# Altium schematic sheet CPU.SchDoc(CPU)
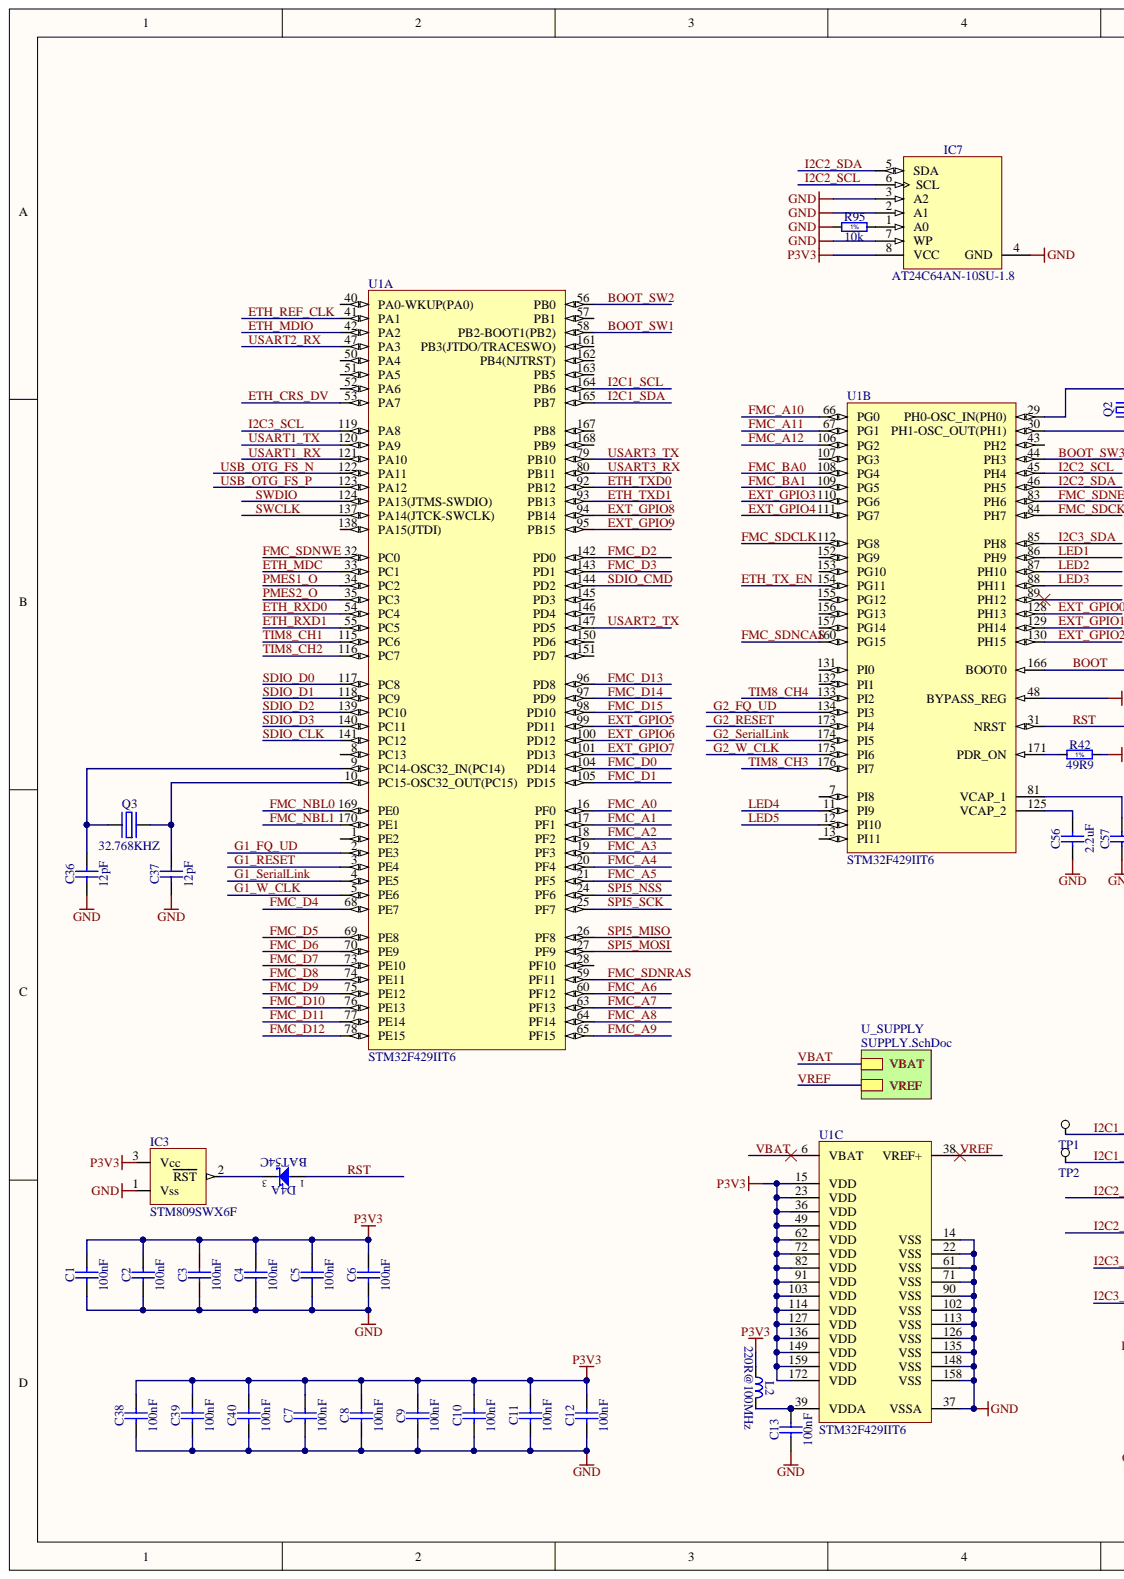
[diagram p0: CPU.SchDoc, left]
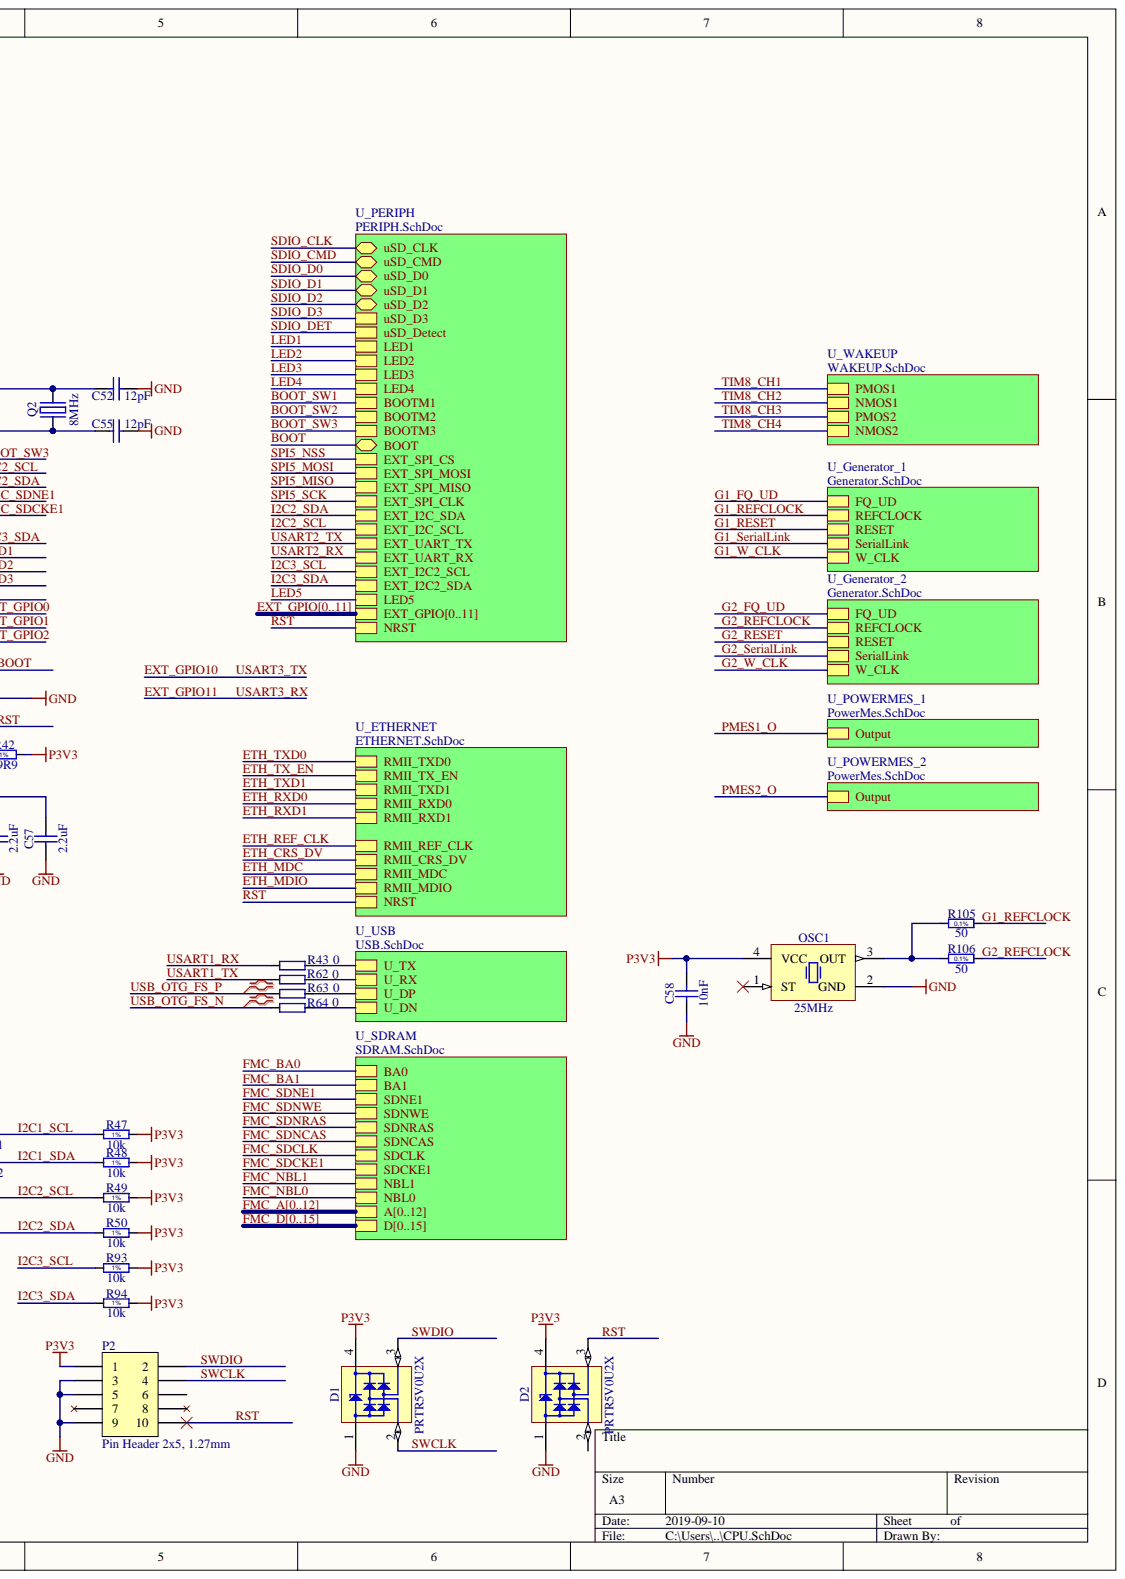
[diagram p1: CPU.SchDoc, right]

## Parts
C1  100nF  [CAPC1005X55N]
C2  100nF  [CAPC1005X55N]
C3  100nF  [CAPC1005X55N]
C4  100nF  [CAPC1005X55N]
C5  100nF  [CAPC1005X55N]
C6  100nF  [CAPC1005X55N]
C7  100nF  [CAPC1005X55N]
C8  100nF  [CAPC1005X55N]
C9  100nF  [CAPC1005X55N]
C10  100nF  [CAPC1005X55N]
C11  100nF  [CAPC1005X55N]
C12  100nF  [CAPC1005X55N]
C13  100nF  [CAPC1005X55N]
C36  12pF  [CAPC1005X55N]
C37  12pF  [CAPC1005X55N]
C38  100nF  [CAPC1005X55N]
C39  100nF  [CAPC1005X55N]
C40  100nF  [CAPC1005X55N]
C52  12pF  [CAPC1005X55N]
C55  12pF  [CAPC1005X55N]
C56  2.2uF  [CAPC1608X87N]
C57  2.2uF  [CAPC1608X87N]
C58  10nF  [CAPC1005X55N]
D1  PRTR5V0U2X  [SOT190P230X110-4N]
D2  PRTR5V0U2X  [SOT190P230X110-4N]
D4  BAT54C  [SOT95P240X130-3N]  (part A)
IC3  STM809SWX6F  [SOT95P229X119-3N]
IC7  AT24C64AN-10SU-1.8  [SOIC127P600X175-8N]
L2  220R@100MHz  [INDC2012X105N]
OSC1  25MHz  [OSC_MICREL_2520]
P2  Pin Header 2x5, 1.27mm  [HDR_2x5-SMD-1.27mm-K]
Q2  8MHz  [XTAL_TXC_7A]
Q3  32.768KHZ  [XTAL_ABRACON_ABS09]
R42  49R9  [RESC1005X40N]
R43  0  [RESC1005X40N]
R47  10k  [RESC1005X40N]
R48  10k  [RESC1005X40N]
R49  10k  [RESC1005X40N]
R50  10k  [RESC1005X40N]
R62  0  [RESC1005X40N]
R63  0  [RESC1005X40N]
R64  0  [RESC1005X40N]
R93  10k  [RESC1005X40N]
R94  10k  [RESC1005X40N]
R95  10k  [RESC1005X40N]
R105  50  [RESC1005X35N]
R106  50  [RESC1005X35N]
TP1  TP  [TP]  (no BOM)
TP2  TP  [TP]  (no BOM)
U1  STM32F429IIT6  [STM-LQFP176_N]  (parts A,B,C)

## Nets
GND: C1.1 C2.1 C3.1 C4.1 C5.1 C6.1 C7.1 C8.1 C9.1 C10.1 C11.1 C12.1 C13.1 C36.1 C37.1 C38.1 C39.1 C40.1 C52.1 C55.1 C56.1 C57.1 C58.1 D1.1 D2.1 IC3.1 IC7.2(A1) IC7.3(A2) IC7.4(GND) IC7.7(WP) OSC1.2(GND) P2.3 P2.5 P2.9 R95.1 U1.14(VSS) U1.22(VSS) U1.37(VSSA) U1.48(BYPASS_REG) U1.61(VSS) U1.71(VSS) U1.90(VSS) U1.102(VSS) U1.113(VSS) U1.126(VSS) U1.135(VSS) U1.148(VSS) U1.158(VSS)
P3V3: C1.2 C2.2 C3.2 C4.2 C5.2 C6.2 C7.2 C8.2 C9.2 C10.2 C11.2 C12.2 C38.2 C39.2 C40.2 C58.2 D1.4 D2.4 IC3.3 IC7.8(VCC) L2.1 OSC1.4(VCC) P2.1 R42.2 R47.2 R48.2 R49.2 R50.2 R93.2 R94.2 U1.15(VDD) U1.23(VDD) U1.36(VDD) U1.49(VDD) U1.62(VDD) U1.72(VDD) U1.82(VDD) U1.91(VDD) U1.103(VDD) U1.114(VDD) U1.127(VDD) U1.136(VDD) U1.149(VDD) U1.159(VDD) U1.172(VDD)
BOOT: U1.166(BOOT0)
BOOT_SW1: U1.58(PB2-BOOT1(PB2))
BOOT_SW2: U1.56(PB0)
BOOT_SW3: U1.44(PH3)
ETH_CRS_DV: U1.53(PA7)
ETH_MDC: U1.33(PC1)
ETH_MDIO: U1.42(PA2)
ETH_REF_CLK: U1.41(PA1)
ETH_RXD0: U1.54(PC4)
ETH_RXD1: U1.55(PC5)
ETH_TX_EN: U1.154(PG11)
ETH_TXD0: U1.92(PB12)
ETH_TXD1: U1.93(PB13)
EXT_GPIO0: U1.128(PH13)  [also labelled EXT_GPIO]
EXT_GPIO1: U1.129(PH14)  [also labelled EXT_GPIO]
EXT_GPIO2: U1.130(PH15)  [also labelled EXT_GPIO]
EXT_GPIO3: U1.110(PG6)  [also labelled EXT_GPIO]
EXT_GPIO4: U1.111(PG7)  [also labelled EXT_GPIO]
EXT_GPIO5: U1.99(PD11)  [also labelled EXT_GPIO]
EXT_GPIO6: U1.100(PD12)  [also labelled EXT_GPIO]
EXT_GPIO7: U1.101(PD13)  [also labelled EXT_GPIO]
EXT_GPIO8: U1.94(PB14)  [also labelled EXT_GPIO]
EXT_GPIO9: U1.95(PB15)  [also labelled EXT_GPIO]
EXT_GPIO10: U1.79(PB10)  [also labelled EXT_GPIO]
EXT_GPIO11: U1.80(PB11)  [also labelled EXT_GPIO]
FMC_A0: U1.16(PF0)  [also labelled FMC_A]
FMC_A1: U1.17(PF1)  [also labelled FMC_A]
FMC_A2: U1.18(PF2)  [also labelled FMC_A]
FMC_A3: U1.19(PF3)  [also labelled FMC_A]
FMC_A4: U1.20(PF4)  [also labelled FMC_A]
FMC_A5: U1.21(PF5)  [also labelled FMC_A]
FMC_A6: U1.60(PF12)  [also labelled FMC_A]
FMC_A7: U1.63(PF13)  [also labelled FMC_A]
FMC_A8: U1.64(PF14)  [also labelled FMC_A]
FMC_A9: U1.65(PF15)  [also labelled FMC_A]
FMC_A10: U1.66(PG0)  [also labelled FMC_A]
FMC_A11: U1.67(PG1)  [also labelled FMC_A]
FMC_A12: U1.106(PG2)  [also labelled FMC_A]
FMC_BA0: U1.108(PG4)
FMC_BA1: U1.109(PG5)
FMC_D0: U1.104(PD14)  [also labelled FMC_D]
FMC_D1: U1.105(PD15)  [also labelled FMC_D]
FMC_D2: U1.142(PD0)  [also labelled FMC_D]
FMC_D3: U1.143(PD1)  [also labelled FMC_D]
FMC_D4: U1.68(PE7)  [also labelled FMC_D]
FMC_D5: U1.69(PE8)  [also labelled FMC_D]
FMC_D6: U1.70(PE9)  [also labelled FMC_D]
FMC_D7: U1.73(PE10)  [also labelled FMC_D]
FMC_D8: U1.74(PE11)  [also labelled FMC_D]
FMC_D9: U1.75(PE12)  [also labelled FMC_D]
FMC_D10: U1.76(PE13)  [also labelled FMC_D]
FMC_D11: U1.77(PE14)  [also labelled FMC_D]
FMC_D12: U1.78(PE15)  [also labelled FMC_D]
FMC_D13: U1.96(PD8)  [also labelled FMC_D]
FMC_D14: U1.97(PD9)  [also labelled FMC_D]
FMC_D15: U1.98(PD10)  [also labelled FMC_D]
FMC_NBL0: U1.169(PE0)
FMC_NBL1: U1.170(PE1)
FMC_SDCKE1: U1.84(PH7)
FMC_SDCLK: U1.112(PG8)
FMC_SDNCAS: U1.160(PG15)
FMC_SDNE1: U1.83(PH6)
FMC_SDNRAS: U1.59(PF11)
FMC_SDNWE: U1.32(PC0)
G1_FQ_UD: U1.2(PE3)
G1_REFCLOCK: R105.2
G1_RESET: U1.3(PE4)
G1_SerialLink: U1.4(PE5)
G1_W_CLK: U1.5(PE6)
G2_FQ_UD: U1.134(PI3)
G2_REFCLOCK: R106.2
G2_RESET: U1.173(PI4)
G2_SerialLink: U1.174(PI5)
G2_W_CLK: U1.175(PI6)
I2C1_SCL: R47.1 TP1.1 U1.164(PB6)
I2C1_SDA: R48.1 TP2.1 U1.165(PB7)
I2C2_SCL: IC7.6(SCL) R49.1 U1.45(PH4)
I2C2_SDA: IC7.5(SDA) R50.1 U1.46(PH5)
I2C3_SCL: R93.1 U1.119(PA8)
I2C3_SDA: R94.1 U1.85(PH8)
LED1: U1.86(PH9)
LED2: U1.87(PH10)
LED3: U1.88(PH11)
LED4: U1.11(PI9)
LED5: U1.12(PI10)
PMES1_O: U1.34(PC2)
PMES2_O: U1.35(PC3)
RST: D2.3 D4.1 P2.10 U1.31(NRST)
SDIO_CLK: U1.141(PC12)
SDIO_CMD: U1.144(PD2)
SDIO_D0: U1.117(PC8)
SDIO_D1: U1.118(PC9)
SDIO_D2: U1.139(PC10)
SDIO_D3: U1.140(PC11)
SPI5_MISO: U1.26(PF8)
SPI5_MOSI: U1.27(PF9)
SPI5_NSS: U1.24(PF6)
SPI5_SCK: U1.25(PF7)
SWCLK: D1.2 P2.4 U1.137(PA14(JTCK-SWCLK))
SWDIO: D1.3 P2.2 U1.124(PA13(JTMS-SWDIO))
TIM8_CH1: U1.115(PC6)
TIM8_CH2: U1.116(PC7)
TIM8_CH3: U1.176(PI7)
TIM8_CH4: U1.133(PI2)
USART1_RX: R43.1 U1.121(PA10)
USART1_TX: R62.2 U1.120(PA9)
USART2_RX: U1.47(PA3)
USART2_TX: U1.147(PD5)
USB_OTG_FS_N: R64.2 U1.122(PA11)
USB_OTG_FS_P: R63.1 U1.123(PA12)
VBAT: U1.6(VBAT)
VREF: U1.38(VREF+)
NetC13_2: C13.2 L2.2 U1.39(VDDA)
NetC36_2: C36.2 Q3.1 U1.9(PC14-OSC32_IN(PC14))
NetC37_2: C37.2 Q3.2 U1.10(PC15-OSC32_OUT(PC15))
NetC52_2: C52.2 Q2.2 U1.29(PH0-OSC_IN(PH0))
NetC55_2: C55.2 Q2.1 U1.30(PH1-OSC_OUT(PH1))
NetC56_2: C56.2 U1.125(VCAP_2)
NetC57_2: C57.2 U1.81(VCAP_1)
NetD2_2: D2.2
NetD4_3: D4.3 IC3.2
NetIC7_1: IC7.1(A0) R95.2
NetOSC1_1: OSC1.1(ST)
NetOSC1_3: OSC1.3(OUT) R105.1 R106.1
NetP2_6: P2.6
NetP2_7: P2.7
NetP2_8: P2.8
NetR42_1: R42.1 U1.171(PDR_ON)
NetR43_2: R43.2
NetR62_1: R62.1
NetR63_2: R63.2
NetR64_1: R64.1
NetU1_1: U1.1(PE2)
NetU1_7: U1.7(PI8)
NetU1_8: U1.8(PC13)
NetU1_13: U1.13(PI11)
NetU1_28: U1.28(PF10)
NetU1_40: U1.40(PA0-WKUP(PA0))
NetU1_43: U1.43(PH2)
NetU1_50: U1.50(PA4)
NetU1_51: U1.51(PA5)
NetU1_52: U1.52(PA6)
NetU1_57: U1.57(PB1)
NetU1_89: U1.89(PH12)
NetU1_107: U1.107(PG3)
NetU1_131: U1.131(PI0)
NetU1_132: U1.132(PI1)
NetU1_138: U1.138(PA15(JTDI))
NetU1_145: U1.145(PD3)
NetU1_146: U1.146(PD4)
NetU1_150: U1.150(PD6)
NetU1_151: U1.151(PD7)
NetU1_152: U1.152(PG9)
NetU1_153: U1.153(PG10)
NetU1_155: U1.155(PG12)
NetU1_156: U1.156(PG13)
NetU1_157: U1.157(PG14)
NetU1_161: U1.161(PB3(JTDO/TRACESWO))
NetU1_162: U1.162(PB4(NJTRST))
NetU1_163: U1.163(PB5)
NetU1_167: U1.167(PB8)
NetU1_168: U1.168(PB9)
SDIO_DET:

## Library data of the parts
C1-C13 C38-C40 = CC0402_100NF_16V_10%_X5R: Non-polarised capacitor; Part Description: SMD Multilayer Chip Ceramic Capacitor; Manufacturer1 Part Number: GRM155R61C104KA88D; Manufacturer: GENERIC; Voltage: 16V; Tolerance: ±10%; TC: X5R; Database Table Name: Capacitors SMD; Value: 100nF; Status: None; Socket: No; SMD: Yes; Sense: No; Pin Count: 2; Part Number: CC0402_100NF_16V_10%_X5R; Mounted: Yes; Manufacturer Part Number: CC0402_100NF_16V_10%_X5R; Manufacturer1 Example: MURATA; Manufacturer1 ComponentHeight: 0.55mm; Library Name: Capacitors.DbLib; LatestRevisionDate: 2013-12-10; HelpURL: \\cern.ch\dfs\Applications\Altium\Datasheets\CC0402_X5R_MURATA_GRM.pdf; CreateDate: 2013-12-10; Component Type: Standard; Component Kind: Standard; Case: 0402; Author: CERN DEM JLC
C36 C37 C52 C55 = CC0402_12PF_50V_5%_C0G: Non-polarised capacitor; Part Description: SMD Multilayer Chip Ceramic Capacitor; Manufacturer1 Part Number: C0402C120J5GACTU; Manufacturer: GENERIC; Voltage: 50V; Tolerance: ±5%; TC: C0G/NP0; Database Table Name: Capacitors SMD; Value: 12pF; Status: Preferred; Socket: No; SMD: Yes; Sense: No; Pin Count: 2; Part Number: CC0402_12PF_50V_5%_C0G; Mounted: Yes; Manufacturer Part Number: CC0402_12PF_50V_5%_C0G; Manufacturer1 Example: KEMET; Manufacturer1 ComponentHeight: 0.55mm; Library Name: Capacitors.DbLib; LatestRevisionDate: 2016-10-04; HelpURL: \\cern.ch\dfs\Applications\Altium\Datasheets\CC0805_C0G_KEMET_(Commercial Grade).pdf; CreateDate: 2016-10-04; Component Type: Standard; Component Kind: Standard; Case: 0402; Author: CERN DEM JLC
C56 C57 = CC0603_2.2UF_16V_10%_X5R: Non-polarised capacitor; Part Description: SMD Multilayer Chip Ceramic Capacitor; Manufacturer1 Part Number: CC0603KRX5R7BB225; Manufacturer: GENERIC; Voltage: 16V; Tolerance: ±10%; TC: X5R; Database Table Name: Capacitors SMD; Value: 2.2uF; Status: Preferred; Socket: No; SMD: Yes; Sense: No; Pin Count: 2; Part Number: CC0603_2.2UF_16V_10%_X5R; Mounted: Yes; Manufacturer Part Number: CC0603_2.2UF_16V_10%_X5R; Manufacturer1 Example: YAGEO; Manufacturer1 ComponentHeight: 0.9mm; Library Name: Capacitors.DbLib; LatestRevisionDate: 2011-04-28; HelpURL: \\cern.ch\dfs\Applications\Altium\Datasheets\CC0805_X5R_PHYCOMP_HC.pdf; CreateDate: 2011-04-28; Component Type: Standard; Component Kind: Standard; Case: 0603; Author: CERN DEM JLC
C58 = CC0402_10NF_16V_10%_X7R: Non-polarised capacitor; Part Description: SMD Multilayer Chip Ceramic Capacitor; Manufacturer1 Part Number: 223878715636; Manufacturer: GENERIC; Voltage: 16V; Tolerance: ±10%; TC: X7R; Database Table Name: Capacitors SMD; Value: 10nF; Status: Not Recommended; Socket: No; SMD: Yes; Sense: No; Pin Count: 2; Part Number: CC0402_10NF_16V_10%_X7R; Mounted: Yes; Manufacturer Part Number: CC0402_10NF_16V_10%_X7R; Manufacturer1 Example: PHYCOMP; Manufacturer1 ComponentHeight: 0.55mm; Library Name: Capacitors.DbLib; LatestRevisionDate: 2007-12-03; HelpURL: \\cern.ch\dfs\Applications\Altium\Datasheets\CC0402_X7R_PHYCOMP.pdf; CreateDate: 2007-12-03; Component Type: Standard; Component Kind: Standard; Case: 0402; Author: CERN DEM JLC
D1 D2 = PRTR5V0U2X: Ultra Low Capacitance Double Rail-to-Rail ESD Protection Diode; Part Description: Ultra Low Capacitance (1pF) Double Rail-to-Rail ESD Protection Diode (8kV); Manufacturer: NXP SEMICONDUCTORS; Voltage: 5.5V; PressFit: No; Database Table Name: Diodes; Bonding: No; Socket: No; SMD: Yes; Sense: No; Pin Count: 4; Part Number: PRTR5V0U2X; PackageDescription: SOT143B, SOT, 1.90mm Pitch; 4 Pin, 1.30mm W X 2.90mm L X 1.10mm H body, IPC Medium Density; Mounted: Yes; Manufacturer Part Number: PRTR5V0U2X; Library Name: ICs And Semiconductors.DbLib; LatestRevisionDate: 2011-03-22; HelpURL: \\cern.ch\dfs\Applications\Altium\Datasheets\PRTR5V0U2X.pdf; Device: PRTR5V0U2X; CreateDate: 2011-03-22; Component Type: Standard; Component Kind: Standard; ComponentHeight: 1.1mm; Case: SOT143B; Author: CERN DEM JLC
D4 = BAT54C: Dual Common Cathode Schottky Diodes; Part Description: Dual Common Cathode Small Signal Schottky Diode; Manufacturer: FAIRCHILD SEMICONDUCTOR; Voltage: 30V; PressFit: No; Power: 200mA; Bonding: No; Socket: No; SMD: Yes; Sense: No; Pin Count: 3; Part Number: BAT54C; PackageDescription: SOT23 3-Leads, Pitch 0.95mm - Body 1.3x2.9mm, IPC Medium Density; Mounted: Yes; Manufacturer Part Number: BAT54C; Library Name: ICs And Semiconductors.DbLib; LatestRevisionDate: 2010-05-27; HelpURL: \\cern.ch\dfs\Applications\Altium\Datasheets\BAT54C.pdf; Device: BAT54C; Database Table Name: Diodes; CreateDate: 2009-09-17; Component Type: Standard; Component Kind: Standard; ComponentHeight: 1.30mm; Case: SOT23-3; Author: CERN DEM JLC
IC3 = VMON_STM809: 2.93V  Reset circuit; Library Name: LIBRARY_SCH.SCHLIB; Component Kind: Standard
IC7 = AT24C64AN-10SU-1.8: 64K (8192 x 8), Two-Wire Serial EEPROM; Part Description: 1.8V, 64K (8192 x 8), Two-Wire Serial EEPROM; Manufacturer: ATMEL; PressFit: No; Database Table Name: Logic; Bonding: No; Status: Obsolete; Socket: No; SMD: Yes; Sense: No; Pin Count: 8; Part Number: AT24C64AN-10SU-1.8; PackageDescription: SOIC 8, Pitch 1.27mm - Body 4x5mm, IPC Medium Density; Mounted: Yes; Manufacturer Part Number: AT24C64AN-10SU-1.8; Library Name: ICs And Semiconductors.DbLib; LatestRevisionDate: 2013-11-26; HelpURL: \\cern.ch\dfs\Applications\Altium\Datasheets\AT24C64AN-10SU-1.8.pdf; Device: AT24C64AN-10SU-1.8; CreateDate: 2013-11-26; Component Type: Standard; Component Kind: Standard; ComponentHeight: 1.75mm; Case: SOIC8; Author: CERN DEM JLC
L2 = IND0805_MURATA_BLM21PG221SN1D: Inductor; Part Description: SMD EMIFIL Suppression Chip Ferrite Bead; Manufacturer: MURATA; Tolerance: ±25%; Resistance: 0.05R; Power: 2A; Database Table Name: Inductors SMD; Value: 220R@100MHz; Status: None; Socket: No; SMD: Yes; Sense: No; Pin Count: 2; Part Number: IND0805_MURATA_BLM21PG221SN1D; Mounted: Yes; Manufacturer Part Number: BLM21PG221SN1D; Library Name: Inductors & Transformers.DbLib; LatestRevisionDate: 2010-01-25; HelpURL: \\cern.ch\dfs\Applications\Altium\Datasheets\IND0402_MURATA_BLM21P.pdf; CreateDate: 2010-01-25; Component Type: Standard; Component Kind: Standard; ComponentHeight: 1.05mm; Case: 0805; Author: CERN DEM JLC
OSC1 = OSC_25MHZ_MICREL_DSC1001DI5-025.0000T: Oscillator 4 pins 1=\ST 2=GND 3=OUT 4=VCC; Part Description: 1.8V-3.3V, ±10ppm, 15pF,-40..85°C, HCMOS/TTL Surface Mount Low-Power Precision CMOS Oscillator (DSC1001 Series); Manufacturer: MICREL; PressFit: No; Database Table Name: Crystals & Oscillators; Bonding: No; Value: 25MHz; Socket: No; SMD: Yes; Sense: No; Pin Count: 4; Part Number: OSC_25MHZ_MICREL_DSC1001DI5-025.0000T; PackageDescription: MICREL SMD Oscillator, QFN, 4 pins, 2.5mm x 2.0mm; Mounted: Yes; Manufacturer Part Number: DSC1001DI5-025.0000T; Library Name: ICs And Semiconductors.DbLib; LatestRevisionDate: 2015-04-01; HelpURL: \\cern.ch\dfs\Applications\Altium\Datasheets\OSC_MICREL_DSC1001.pdf; CreateDate: 2015-01-22; Component Type: Standard; Component Kind: Standard; ComponentHeight: 0.9mm; Author: CERN DEM JLC
P2 = Pin Header 2x5 SMD, 1.27mm, KS: Pin Header 2x5, 1.27mm (50mil), SMD, Keying Shroud; Manufacturer Part Number: 3132-10MS0BK00R1; Manufacturer: WCON; 2nd source part number: FTSH-105-01-F-DV-K; Database Table Name: Connectors$; Artikkelnr Simpro: N.A.; Artikkelnr Noca: 55010009; Value: Pin Header 2x5, 1.27mm; Supplier part number: 3132-10MS0BK00R1; Supplier: Cabcon; Published: 2016-01-22; Pin Count: 10; Part Number: Pin Header 2x5 SMD, 1.27mm, KS; Library Name: Nordic Passive DB.DbLib; LatestRevisionDate: 2016-04-21; Footprint Doc: HDR_2x5-SMD-1.27mm; Datasheet: \\hizz\pro\app9717\Datasheet\connectores\W-CON\3132-XXMXXBK00XX.pdf; Component Kind: Standard; 2nd source Supplier: Samtec; Publisher: Nordic Semiconductor
Q2 = XTAL_8MHZ_TXC_7A-8.000MAAE-T: Quartz Crystal; Part Description: Tolerance: ±30ppm, Stability: ±30ppm, -20°C to +70°C, 12pF, SMD Glass Seam Sealing Crystal, 7A Series; Manufacturer: TXC CORPORATION; PressFit: No; Database Table Name: Crystals & Oscillators; Bonding: No; Value: 8MHz; Socket: No; SMD: Yes; Sense: No; Pin Count: 2; Part Number: XTAL_8MHZ_TXC_7A-8.000MAAE-T; PackageDescription: 2 Pins, 3.2x5mm, SMD Glass Seam Sealing Crystal, 7A Serie; Mounted: Yes; Manufacturer Part Number: 7A-8.000MAAE-T; Library Name: ICs And Semiconductors.DbLib; LatestRevisionDate: 2014-11-12; HelpURL: \\cern.ch\dfs\Applications\Altium\Datasheets\XTAL_TXC_7A.pdf; CreateDate: 2014-10-09; Component Type: Standard; Component Kind: Standard; ComponentHeight: 1.2mm; Author: CERN DEM JLC
Q3 = XTAL_32.768KHZ_ABRACON_ABS09-32.768KHZ-T: Quartz Crystal; Part Description: Tolerance: ±20ppm, Stability: ±5ppm, -40°C to +85°C, 12.5pF, SMD Low Profile Crystal, ABS09 Series; PressFit: No; Database Table Name: Crystals & Oscillators; Bonding: No; Value: 32.768KHZ; Socket: No; SMD: Yes; Sense: No; Pin Count: 2; Part Number: XTAL_32.768KHZ_ABRACON_ABS09-32.768KHZ-T; PackageDescription: 2 Pins, 4.1x1.5mm, SMD Low Profile Crystal, ABS09 Serie; Mounted: Yes; Manufacturer Part Number: ABS09-32.768KHZ-T; Manufacturer: ABRACON; Library Name: ICs And Semiconductors.DbLib; LatestRevisionDate: 2014-10-16; HelpURL: \\cern.ch\dfs\Applications\Altium\Datasheets\XTAL_ABRACON_ABS09.pdf; CreateDate: 2014-10-16; Component Type: Standard; Component Kind: Standard; ComponentHeight: 0.9mm; Author: CERN DEM JLC
R42 = R0402_49R9_1%_0.0625W_100PPM: Resistor - 1%; Part Description: General Purpose Thick Film Chip Resistor; Manufacturer1 Part Number: 232270674999L or RC0402FR-0749R9L; Manufacturer: GENERIC; TC: ±100ppm/°C; PressFit: No; Database Table Name: Resistors SMD; Value: 49R9; Tolerance: ±1%; Status: Not Recommended; Socket: No; SMD: Yes; Sense: No; Power: 0.0625W; Pin Count: 2; Part Number: R0402_49R9_1%_0.0625W_100PPM; Mounted: Yes; Manufacturer Part Number: R0402_49R9_1%_0.0625W_100PPM; Manufacturer1 Example: YAGEO PHYCOMP; Manufacturer1 ComponentHeight: 0.4mm; Library Name: Resistors.DbLib; LatestRevisionDate: 2012-10-24; HelpURL: \\cern.ch\dfs\Applications\Altium\Datasheets\R0402_Phycomp_RC0402.pdf; CreateDate: 2012-10-24; Component Type: Standard; Component Kind: Standard; Case: 0402; Author: CERN DEM JLC
R43 R62-R64 = R0402_0R_JUMPER: Resistor; Part Description: 1A (0.05R Max DC Resistance) Zero Ohm Jumper; Manufacturer1 Part Number: 232270591001L; Manufacturer: GENERIC; PressFit: No; Database Table Name: Resistors SMD; Value: 0; Status: Not Recommended; Socket: No; SMD: Yes; Sense: No; Pin Count: 2; Part Number: R0402_0R_JUMPER; Mounted: Yes; Manufacturer Part Number: R0402_0R_JUMPER; Manufacturer1 Example: YAGEO PHYCOMP; Manufacturer1 ComponentHeight: 0.4mm; Library Name: Resistors.DbLib; LatestRevisionDate: 2012-10-17; HelpURL: \\cern.ch\dfs\Applications\Altium\Datasheets\R0402_Phycomp_RC0402.pdf; CreateDate: 2007-12-03; Component Type: Standard; Component Kind: Standard; Case: 0402; Author: CERN DEM JLC
R47-R50 R93-R95 = R0402_10K_1%_0.0625W_100PPM: Resistor - 1%; Part Description: General Purpose Thick Film Chip Resistor; Manufacturer1 Part Number: 232270671003L; Manufacturer: GENERIC; TC: ±100ppm/°C; PressFit: No; Database Table Name: Resistors SMD; Value: 10k; Tolerance: ±1%; Status: Not Recommended; Socket: No; SMD: Yes; Sense: No; Power: 0.0625W; Pin Count: 2; Part Number: R0402_10K_1%_0.0625W_100PPM; Mounted: Yes; Manufacturer Part Number: R0402_10K_1%_0.0625W_100PPM; Manufacturer1 Example: YAGEO PHYCOMP; Manufacturer1 ComponentHeight: 0.4mm; Library Name: Resistors.DbLib; LatestRevisionDate: 2012-10-17; HelpURL: \\cern.ch\dfs\Applications\Altium\Datasheets\R0402_Phycomp_RC0402.pdf; CreateDate: 2007-12-03; Component Type: Standard; Component Kind: Standard; Case: 0402; Author: CERN DEM JLC
R105 R106 = R0402_50R_0.1%_0.05W_25PPM: Resistor - 0.1%; Part Description: Thin Film Precision Resistor; Manufacturer1 Part Number: FC0402E50R0BTBS; Manufacturer: GENERIC; TC: ±25ppm/°C; PressFit: No; Power: 0.05W; Database Table Name: Resistors SMD; Value: 50; Tolerance: ±0.1%; Status: Not Recommended; Socket: No; SMD: Yes; Sense: No; Pin Count: 2; Part Number: R0402_50R_0.1%_0.05W_25PPM; Mounted: Yes; Manufacturer Part Number: R0402_50R_0.1%_0.05W_25PPM; Manufacturer1 Example: VISHAY DALE; Manufacturer1 ComponentHeight: 0.38mm; Library Name: Resistors.DbLib; LatestRevisionDate: 2016-08-11; HelpURL: \\cern.ch\dfs\Applications\Altium\Datasheets\R0402_VISHAY_FC.pdf; CreateDate: 2016-08-11; Component Type: Standard; Component Kind: Standard; Case: 0402; Author: CERN DEM JMW
TP1 TP2 = TP: Testpoint; Library Name: Charger_base_station v2.2.IntLib
U1 = STM32F429IIT6: ARM Cortex-M4 32-bit MCU+FPU, 225 DMIPS, 2048 kB Flash, 256 kB Internal RAM, 140 I/Os, 176-pin LQFP, -40 to 85 degC, Tray; Manufacturer: STMicroelectronics; Supply Voltage(Vcc) min (V): 1.8; Supply Voltage(Vcc) max (V): 3.6; Operating Frequency(F) (Processor speed) (MHz): 180; Internal RAM Size (kB): 256; I/Os (High Current): 140; FLASH Size (Prog) (kB): 2048; D/A Converter: 2x12-bit; Supplier Part Number 3: 511-STM32F429IIT6; Supplier Part Number 2: 06X3672; Supplier Part Number 1: 497-14054-ND; Supplier 3: Mouser; Supplier 2: Newark; Supplier 1: Digi-Key; PartNumber: STM32F429IIT6; Packing: Tray; PackageVersion: Rev. 4, 06/2013; PackageReference: LQFP176; PackageDescription: 176-Pin Low Profile Quad Flat Package, 24 x 24 mm Body, 0.5 mm Pitch; Mounting Technology: Surface Mount; Library Name: STMicroelectronics STM32 F4.IntLib; DatasheetVersion: Rev. 2; Core: ARM Cortex-M4; ComponentLink3URL: http://www.st.com/st-web-ui/static/active/en/resource/technical/document/datasheet/DM00035129.pdf; ComponentLink3Description: Package Specification; ComponentLink2URL: http://www.st.com/st-web-ui/static/active/en/resource/technical/document/data_brief/DM00053488.pdf; ComponentLink2Description: Datasheet; ComponentLink1URL: http://www.st.com/web/en/home.html; ComponentLink1Description: Manufacturer URL; Component Kind: Standard
